# Altium schematic sheet Connectors.SchDoc(Connectors)
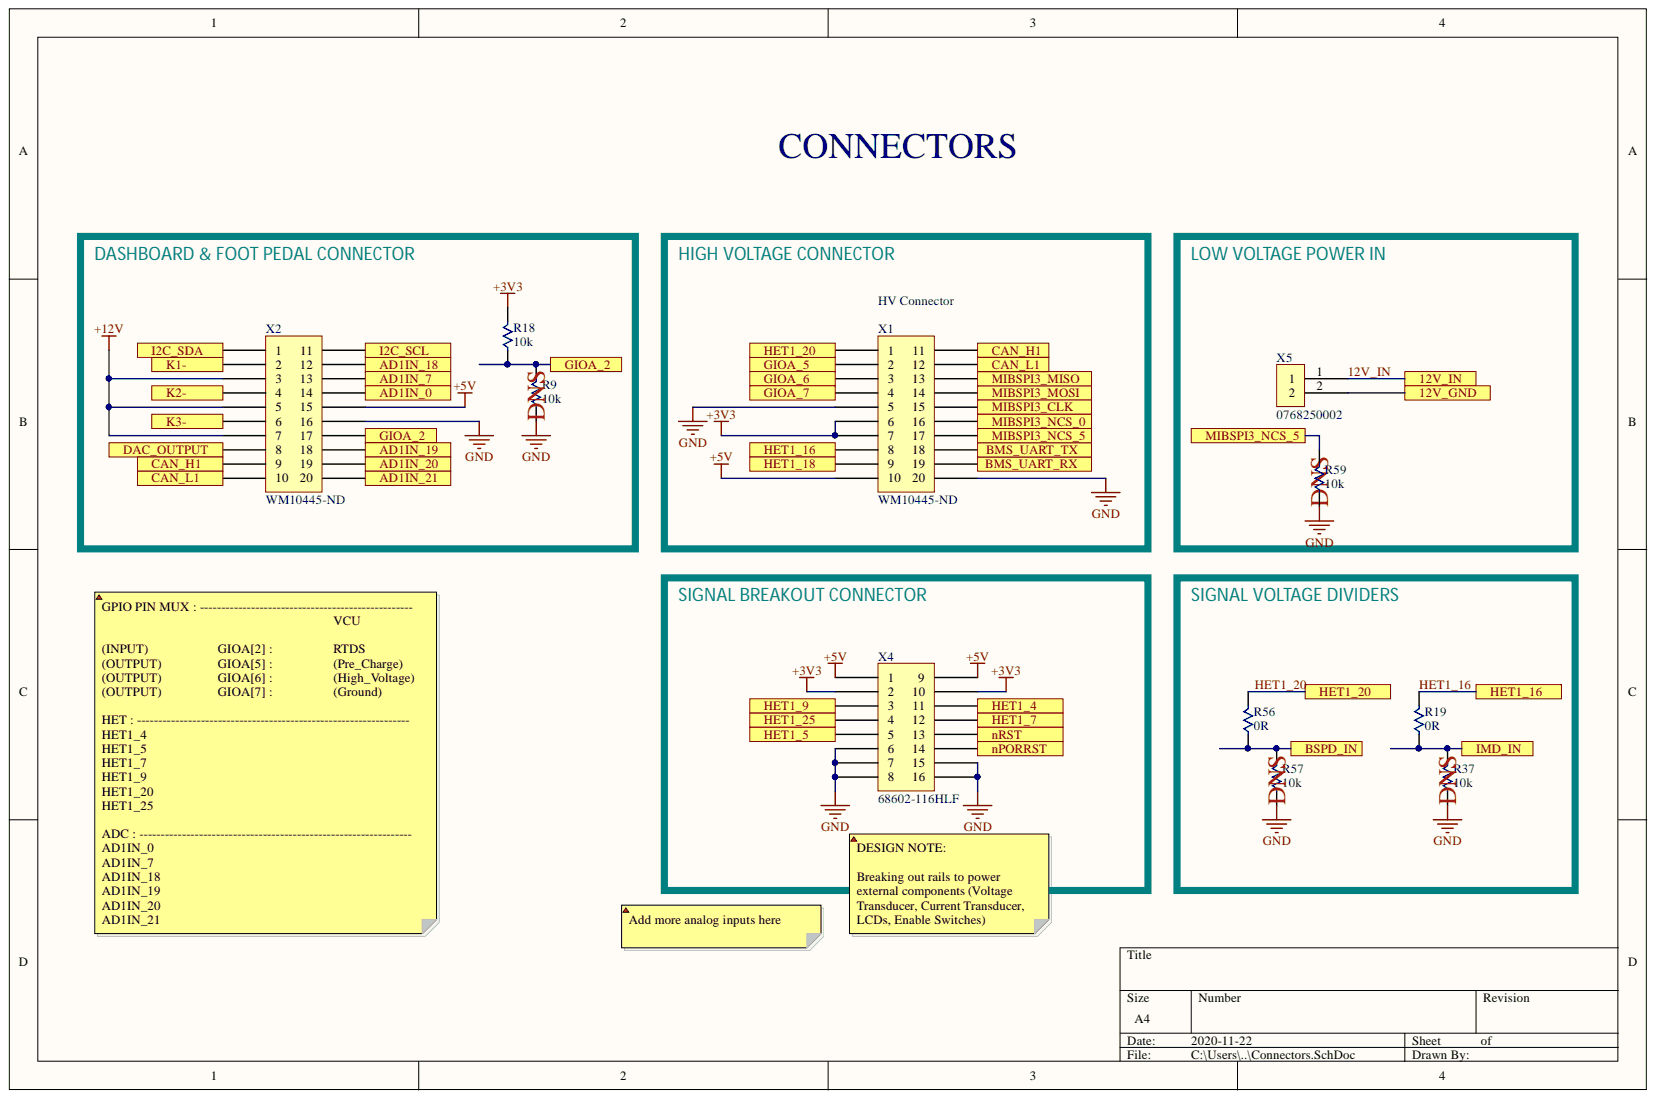
[diagram p0: Connectors.SchDoc]

## Parts
R9  10k  [R0402]
R18  10k  [R0402]
R19  0R  [R0402]
R37  10k  [R0402]
R56  0R  [R0402]
R57  10k  [R0402]
R59  10k  [R0402]
X1  WM10445-ND  [MOLEX_20pin]
X2  WM10445-ND  [MOLEX_20pin]
X4  68602-116HLF  [16PIN_HEADER]
X5  0768250002  [MOLEX_2PIN_MEGAFIT]

## Ports
12V_GND, 12V_IN, AD1IN_0, AD1IN_7, AD1IN_18, AD1IN_19, AD1IN_20, AD1IN_21, BMS_UART_RX, BMS_UART_TX, BSPD_IN, CAN_H1, CAN_L1, DAC_OUTPUT, GIOA_2, GIOA_5, GIOA_6, GIOA_7, HET1_4, HET1_5, HET1_7, HET1_9, HET1_16, HET1_18, HET1_20, HET1_25, I2C_SCL, I2C_SDA, IMD_IN, K1-, K2-, K3-, MIBSPI3_CLK, MIBSPI3_MISO, MIBSPI3_MOSI, MIBSPI3_NCS_0, MIBSPI3_NCS_5, nPORRST, nRST

## Nets
+3V3: R18.2 X1.6 X1.7 X4.2 X4.10
+5V: X1.10 X2.15 X4.1 X4.9
+12V: X2.3 X2.5 X2.7
GND: R9.1 R37.1 R57.1 R59.1 X1.5 X1.20 X2.16 X4.6 X4.7 X4.8 X4.15 X4.16
12V_GND: X5.2 ; port 12V_GND
12V_IN: X5.1 ; port 12V_IN
AD1IN_0: X2.14 ; port AD1IN_0
AD1IN_7: X2.13 ; port AD1IN_7
AD1IN_18: X2.12 ; port AD1IN_18
AD1IN_19: X2.18 ; port AD1IN_19
AD1IN_20: X2.19 ; port AD1IN_20
AD1IN_21: X2.20 ; port AD1IN_21
BMS_UART_RX: X1.19 ; port BMS_UART_RX
BMS_UART_TX: X1.18 ; port BMS_UART_TX
BSPD_IN: R56.1 R57.2 ; port BSPD_IN
CAN_H1: X1.11 X2.9 ; port CAN_H1
CAN_L1: X1.12 X2.10 ; port CAN_L1
DAC_OUTPUT: X2.8 ; port DAC_OUTPUT
GIOA_2: R9.2 R18.1 X2.17 ; port GIOA_2
GIOA_5: X1.2 ; port GIOA_5
GIOA_6: X1.3 ; port GIOA_6
GIOA_7: X1.4 ; port GIOA_7
HET1_4: X4.11 ; port HET1_4
HET1_5: X4.5 ; port HET1_5
HET1_7: X4.12 ; port HET1_7
HET1_9: X4.3 ; port HET1_9
HET1_16: R19.2 X1.8 ; port HET1_16
HET1_18: X1.9 ; port HET1_18
HET1_20: R56.2 X1.1 ; port HET1_20
HET1_25: X4.4 ; port HET1_25
I2C_SCL: X2.11 ; port I2C_SCL
I2C_SDA: X2.1 ; port I2C_SDA
IMD_IN: R19.1 R37.2 ; port IMD_IN
K1-: X2.2 ; port K1-
K2-: X2.4 ; port K2-
K3-: X2.6 ; port K3-
MIBSPI3_CLK: X1.15 ; port MIBSPI3_CLK
MIBSPI3_MISO: X1.13 ; port MIBSPI3_MISO
MIBSPI3_MOSI: X1.14 ; port MIBSPI3_MOSI
MIBSPI3_NCS_0: X1.16 ; port MIBSPI3_NCS_0
MIBSPI3_NCS_5: R59.2 X1.17 ; port MIBSPI3_NCS_5
nPORRST: X4.14 ; port nPORRST
nRST: X4.13 ; port nRST

## Library data of the parts
R9 R18 R37 R57 R59 = RC0402JR-0710KL: RES SMD 10K OHM 5% 1/16W 0402; Manufacturer: Yageo; Voltage Rating: 50V; Temperature Coefficient: 100ppm/°C; Resistance: 10kR; Power Rating: 62.5mW; Max Power Dissipation: 63mW; Width: 0.02inch; Tolerance: 5%; Termination: SMD/SMT; Supplier Part Number 1: 311-10KJRCT-ND; Supplier 1: Digi-Key; Stock 1: 47303622; REACH SVHC: Unknown; Pricing 1: 1=0.1, 10=0.018, 100=0.0073, 1000=0.0033, 2500=0.0029, 5000=0.0024 (USD); Packaging: Tape and Reel; Package Quantity: 1; Number of Terminations: 2; Min Operating Temperature: -55°C; Military Standard: Not; Max Operating Temperature: 155°C; Manufacturer Part Number: RC0402JR-0710KL; Length: 1mm; Lead Free: Lead Free; Height: 0.016inch; Features: Moisture Resistant; Depth: 0.5mm; Composition: Thick Film; Component Kind: Standard; Case Code (Metric): 1005; Case Code (Imperial): 0402; Case/Package: 0402; Library Name: PHANTOM_LIBRARY.SchLib
R19 R56 = RC0402JR-070RL: RES SMD 0 OHM 1/16W 0402; Manufacturer: Yageo; Voltage Rating: 50V; Tolerance: 5%; Temperature Coefficient: 200ppm/°C; Resistance: 0mR; Power Rating: 62.5mW; Max Power Dissipation: 63mW; Width: 0.02inch; Termination: SMD/SMT; Supplier Part Number 1: 311-0.0JRCT-ND; Supplier 1: Digi-Key; Stock 1: 44333412; REACH SVHC: Unknown; Radiation Hardening: No; Pricing 1: 1=0.1, 10=0.017, 25=0.0124, 50=0.0096, 100=0.007, 250=0.0054, 500=0.0043, 1000=0.0032, 5000=0.0023 (USD); Packaging: Tape and Reel; Package Quantity: 1; Number of Terminations: 2; Min Operating Temperature: -55°C; Military Standard: Not; Max Operating Temperature: 155°C; Manufacturer Part Number: RC0402JR-070RL; Length: 1mm; Lead Free: Lead Free; Height: 0.016inch; Features: Moisture Resistant; Depth: 0.5mm; Contact Plating: Matte,Tin; Composition: Thick Film; Component Kind: Standard; Case Code (Metric): 1005; Case Code (Imperial): 0402; Case/Package: 0402; Library Name: PHANTOM_LIBRARY.SchLib
X1 X2 = 0346916200: CONN_20Positions Molex Stac64 Header, Shrouded Connector 0.100" (2.54mm) Through Hole, Right Angle Tin; Row Spacing: 0.1inch; Number of Positions: 20; Connector Type: Male Pin; Termination: Solder; Supplier Part Number 1: WM10445-ND; Supplier 1: Digi-Key; Stock 1: 334; Pricing 1: 1=3.07, 10=2.946, 100=2.4546, 500=2.0864, 1000=1.7796, 5000=1.5955 (USD); Pitch: 0.1inch; Packaging: Tray; Package Quantity: 560; Number of Rows: 2; Manufacturer Part Number: 0346916200; Manufacturer: Molex; Contact Plating: Tin; ComponentLink1URL: https://datasheet.ciiva.com/29142/987651-0141-29142848.pdf; ComponentLink1Description: https://datasheet.ciiva.com/29142/987651-0141-29142848.pdf; Component Kind: Standard; Colour: Black; Case/Package: 100; Library Name: PHANTOM_LIBRARY.SchLib
X4 = 68602-116HLF: CONN_HeaderPins16pos1  6 Positions Header, Unshrouded Connector 0.100" (2.54mm) Through Hole Gold or Gold, GXT™; Manufacturer: Amphenol FCI; Voltage Rating: 1.5kV; Row Spacing: 0.1inch; Number of Positions: 16; Mating Post Length: 5.84mm; Insulation Resistance: 5GR; Gender: Male; Current Rating: 3A; Connector Type: Male Pin; Termination Post Length: 3.81mm; Termination: Solder; Supplier Part Number 1: 609-3364-ND; Supplier 1: Digi-Key; Stock 1: 1898; REACH SVHC: No SVHC; Radiation Hardening: No; Pricing 1: 1=0.79, 10=0.698, 100=0.6019, 500=0.4925, 1000=0.4378, 5000=0.383, 10000=0.3639 (USD); Pitch: 2.54mm; Packaging: Bulk; Package Quantity: 100; Orientation: Straight; Number of Rows: 2; Number of Contacts: 16; Mount: Through Hole; Min Operating Temperature: -65°C; Max Voltage Rating (AC): 1.5kV; Max Operating Temperature: 125°C; Manufacturer Part Number: 68602-116HLF; Length: 20.32mm; Housing Material: Thermoplastic; Housing Colour: Black; Flammability Rating: UL94 V-0; Depth: 4.83mm; Contact Plating: Nickel,Gold,GXT; Contact Mating Length: 0.23inch; Contact Material: Bronze; Contact Gender: Male; ComponentLink4URL: https://datasheet.ciiva.com/5157/67996-225486-5157197.pdf; ComponentLink4Description: https://datasheet.ciiva.com/5157/67996-225486-5157197.pdf; ComponentLink3URL: https://datasheet.ciiva.com/728/67996-728940.pdf; ComponentLink3Description: https://datasheet.ciiva.com/728/67996-728940.pdf; ComponentLink2URL: https://datasheet.ciiva.com/9465/67996-9465931.pdf; ComponentLink2Description: https://datasheet.ciiva.com/9465/67996-9465931.pdf; ComponentLink1URL: https://datasheet.ciiva.com/16726/67996-16726040.pdf; ComponentLink1Description: https://datasheet.ciiva.com/16726/67996-16726040.pdf; Component Kind: Standard; Colour: Black; Library Name: PHANTOM_LIBRARY.SchLib
X5 = 0768250002: CONN_2Position_MEGAFIT Molex Through Hole, Right Angle Tin; Row Spacing: 0.224inch; Number of Positions: 2; Connector Type: Male Pin; Termination: Solder; Supplier Part Number 1: WM11969-ND; Supplier 1: Digi-Key; Stock 1: 2862; Pricing 1: 1=1.08, 10=0.946, 25=0.89, 50=0.853, 100=0.816, 250=0.7418, 500=0.6676, 1000=0.5934, 2500=0.5378 (USD); Packaging: Tray; Number of Rows: 2; Mount: Through Hole; Manufacturer Part Number: 0768250002; Manufacturer: Molex; Fastening Type: Ramp; Contact Plating: Tin; ComponentLink1URL: http://www.molex.com/pdm_docs/ps/PS-76823-100.pdf; ComponentLink1Description: http://www.molex.com/pdm_docs/ps/PS-76823-100.pdf; Component Kind: Standard; Colour: Black; Library Name: PHANTOM_LIBRARY.SchLib
